# Altium schematic sheet Power_Sheet.SchDoc(U_Power_Sheet)
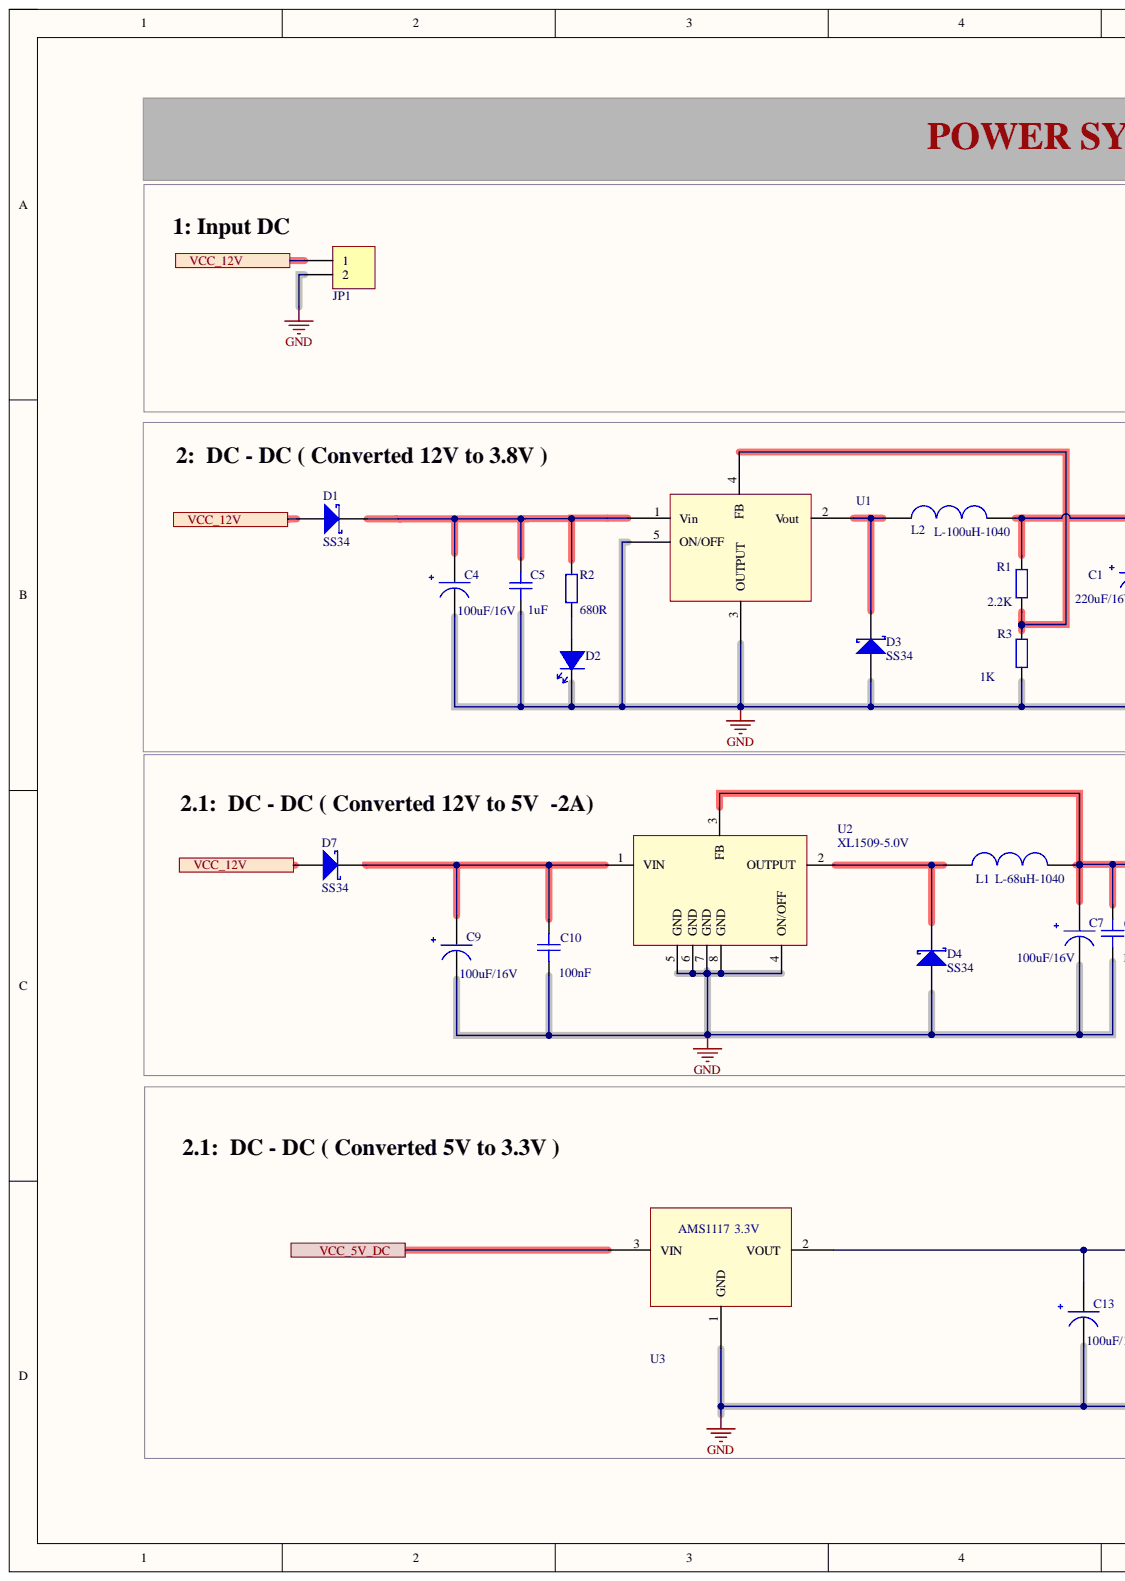
[diagram p0: Power_Sheet.SchDoc, left]
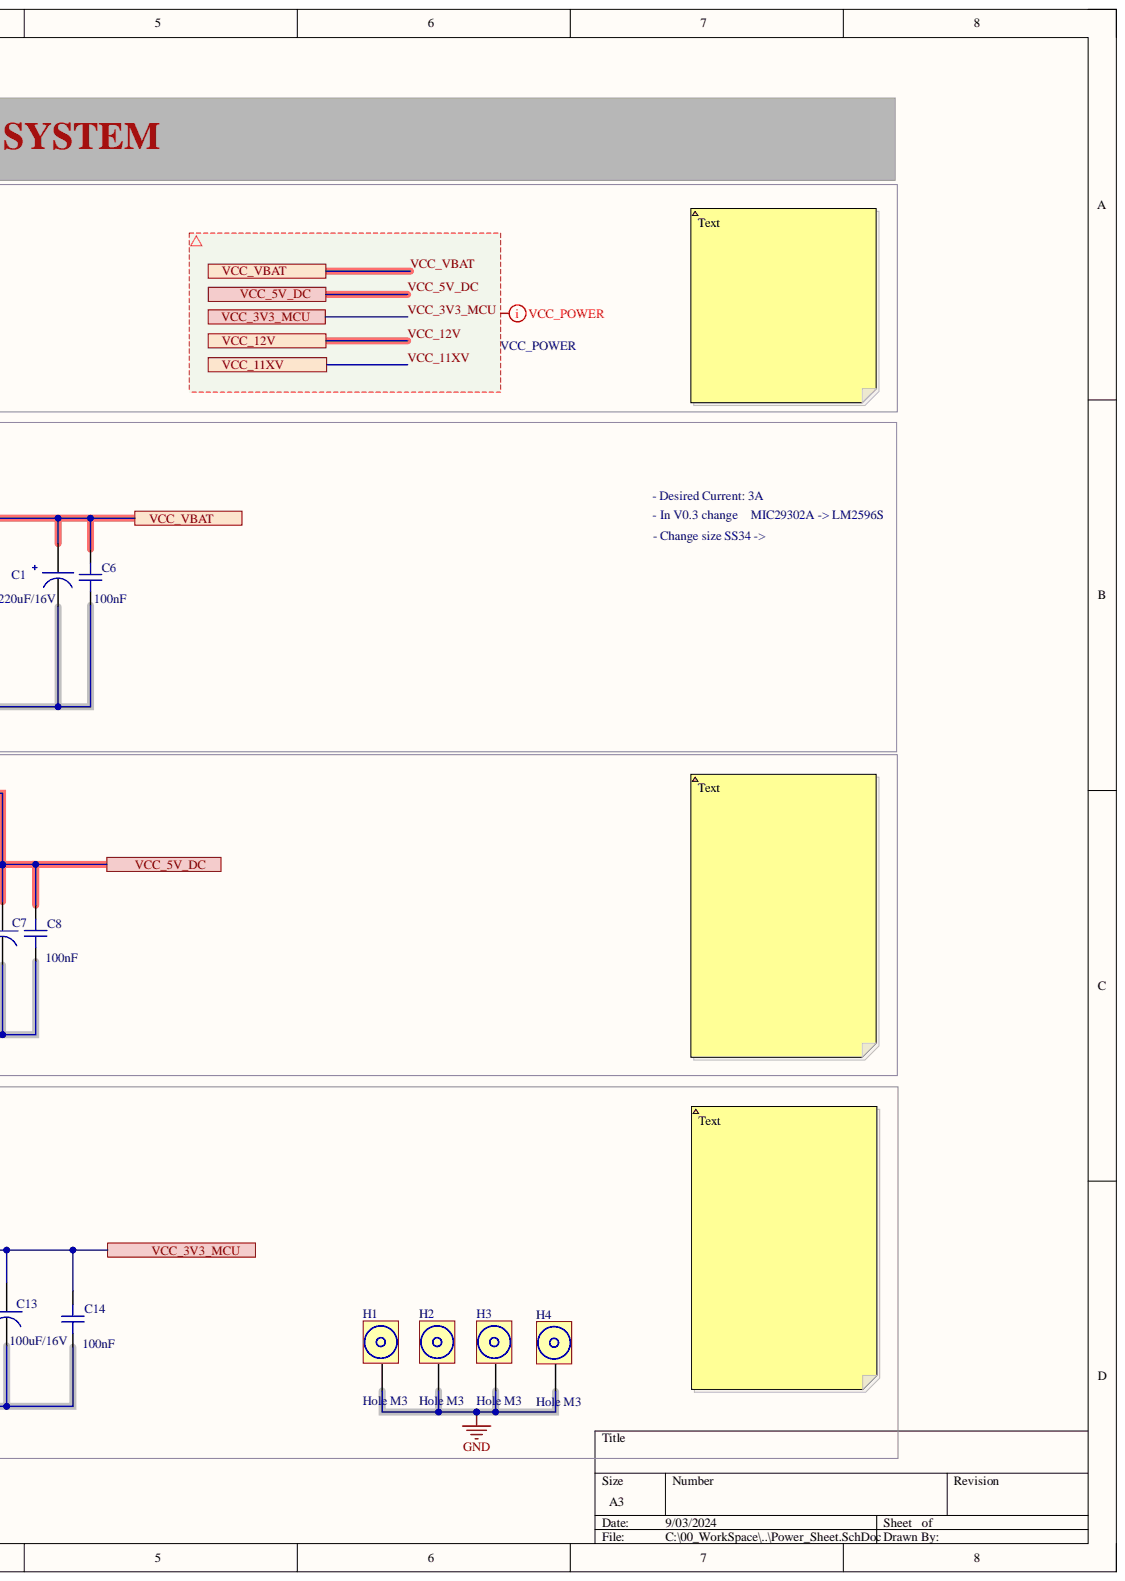
[diagram p1: Power_Sheet.SchDoc, right]

## Parts
C1  220uF/16V  [CAPACITOR 6.3x7.7mm]
C4  100uF/16V  [CAP 6.3MMx5.4MM]
C5  1uF  [CAPC-0603 (1608)]
C6  100nF  [CAPC-0603 (1608)]
C7  100uF/16V  [CAP 6.3MMx5.4MM]
C8  100nF  [CAPC-0603 (1608)]
C9  100uF/16V  [CAP 6.3MMx5.4MM]
C10  100nF  [CAPC-0603 (1608)]
C13  100uF/16V  [CAP 6.3MMx5.4MM]
C14  100nF  [CAPC-0603 (1608)]
D1  SS34  [DIODE_DO214AC_SMA]
D2  LED_0805_PW  [LED_0805_PW]  (value not drawn)
D3  SS34  [DIODE_DO214AC_SMA]
D4  SS34  [DIODE_DO214AC_SMA]
D7  SS34  [DIODE_DO214AC_SMA]
H1  Hole M3  [Hole Size 3M]
H2  Hole M3  [Hole Size 3M]
H3  Hole M3  [Hole Size 3M]
H4  Hole M3  [Hole Size 3M]
JP1  Header 2_1  [HED2]  (value not drawn)
L1  L-68uH-1040  [68uH - 2A - 1040]
L2  L-100uH-1040  [68uH - 2A - 1040]
R1  2.2K  [RESC-0603 (1608)]
R2  680R  [RESC-0603 (1608)]
R3  1K  [RESC-0603 (1608)]
U1  LM2596S  - ADJ  [LM2596S - ADJ]  (value not drawn)
U2  XL1509-5.0V  [XL1509-5V]
U3  AMS1117 - 3.3V  [AMS1117 -3.3V]

## Ports
VCC_3V3_MCU, VCC_5V_DC, VCC_11XV, VCC_12V, VCC_VBAT

## Nets
GND: C1.2 C4.2 C5.1 C6.1 C7.2 C8.1 C9.2 C10.1 C13.2 C14.1 D2.2 D3.1 D4.1 H1.1 H2.1 H3.1 H4.1 JP1.2 R3.1 U1.3(OUTPUT) U1.5(ON/OFF) U2.4(ON/OFF) U2.5(GND) U2.6(GND) U2.7(GND) U2.8(GND) U3.1
VCC_3V3_MCU: C13.1 C14.2 U3.2 ; port VCC_3V3_MCU
VCC_5V_DC: C7.1 C8.2 L1.2 U2.3(FB) U3.3 ; port VCC_5V_DC
VCC_12V: D1.1 D7.1 JP1.1 ; port VCC_12V
VCC_VBAT: C1.1 C6.2 L2.2 R1.2 ; port VCC_VBAT
NetC4_1: C4.1 C5.2 D1.2 R2.2 U1.1(Vin)
NetC9_1: C9.1 C10.2 D7.2 U2.1(VIN)
NetD2_1: D2.1 R2.1
NetD3_2: D3.2 L2.1 U1.2(Vout)
NetD4_2: D4.2 L1.1 U2.2(OUTPUT)
NetR1_1: R1.1 R3.2 U1.4(FB)
VCC_11XV: ; port VCC_11XV

## Library data of the parts
C1 = CAP 220uF: Capacitor 220uF - 16V. Size 6.3x7,7mm; Component Kind: Standard; Library Name: FM_Components.IntLib
C4 C7 C9 C13 = CAP 100uF: Capacitor 100uF - 16V. Size 6.3x5.4mm; Component Kind: Standard; Library Name: FM_Components.IntLib
C5 C6 C8 C10 C14 = CAPC NF 0603: CAP SMD, Not Fill, 0603; Value: NF; Category: CAPACITOR; Component Kind: Standard; Library Name: BYTECH_HW_LIB_2019.IntLib; Solder: Not Fill
D1 D3 D4 D7 = SS34: DIODE SCHOTTKY 40V 3A SMA; Solder: SMT; Component Kind: Standard; Library Name: BYTECH_HW_LIB_2019.IntLib; MPN: SS34; Value: SS34
JP1 = Header 2_1: Header, 2-Pin; Manufacturer: Generic Components; Class: Connector; Component Kind: Standard; Value: Header 2; Library Name: syhaunguyen.IntLib
L2 = L-68uH-1040: Component Kind: Standard; Library Name: FM_Components.IntLib
R1-R3 = CRCW06035R10FKEA: RES SMD 5.1 OHM 1% 1/10W 0603; MFR: Vishay Dale; Solder: SMT; Value: 5.1R; Category: RESISTOR; Component Kind: Standard; Library Name: BYTECH_HW_LIB_2019.IntLib; MPN: CRCW06035R10FKEA; PinCount: 2
U1 = LM2596S: Component Kind: Standard; Description: LM2596S; Library Name: FM_Components.IntLib
U2 = XL1509-5V: XL1509-5V FROM XLSEMI; Component Kind: Standard; Library Name: FM_Components.IntLib
D2 = LED_0805_PW: Component Kind: Standard; Library Name: FM_Components.IntLib
H1-H4 = Hole M3: Component Kind: Standard; Library Name: FM_Components.IntLib
L1 = L-68uH-1040: Component Kind: Standard; Library Name: FM_Components.IntLib
U3 = AMS1117 - 3.3V: Component Kind: Standard; Library Name: FM_Components.IntLib
